# Altium schematic sheet BP6A_EVK_4.SchDoc(BP6A_EVK_4)
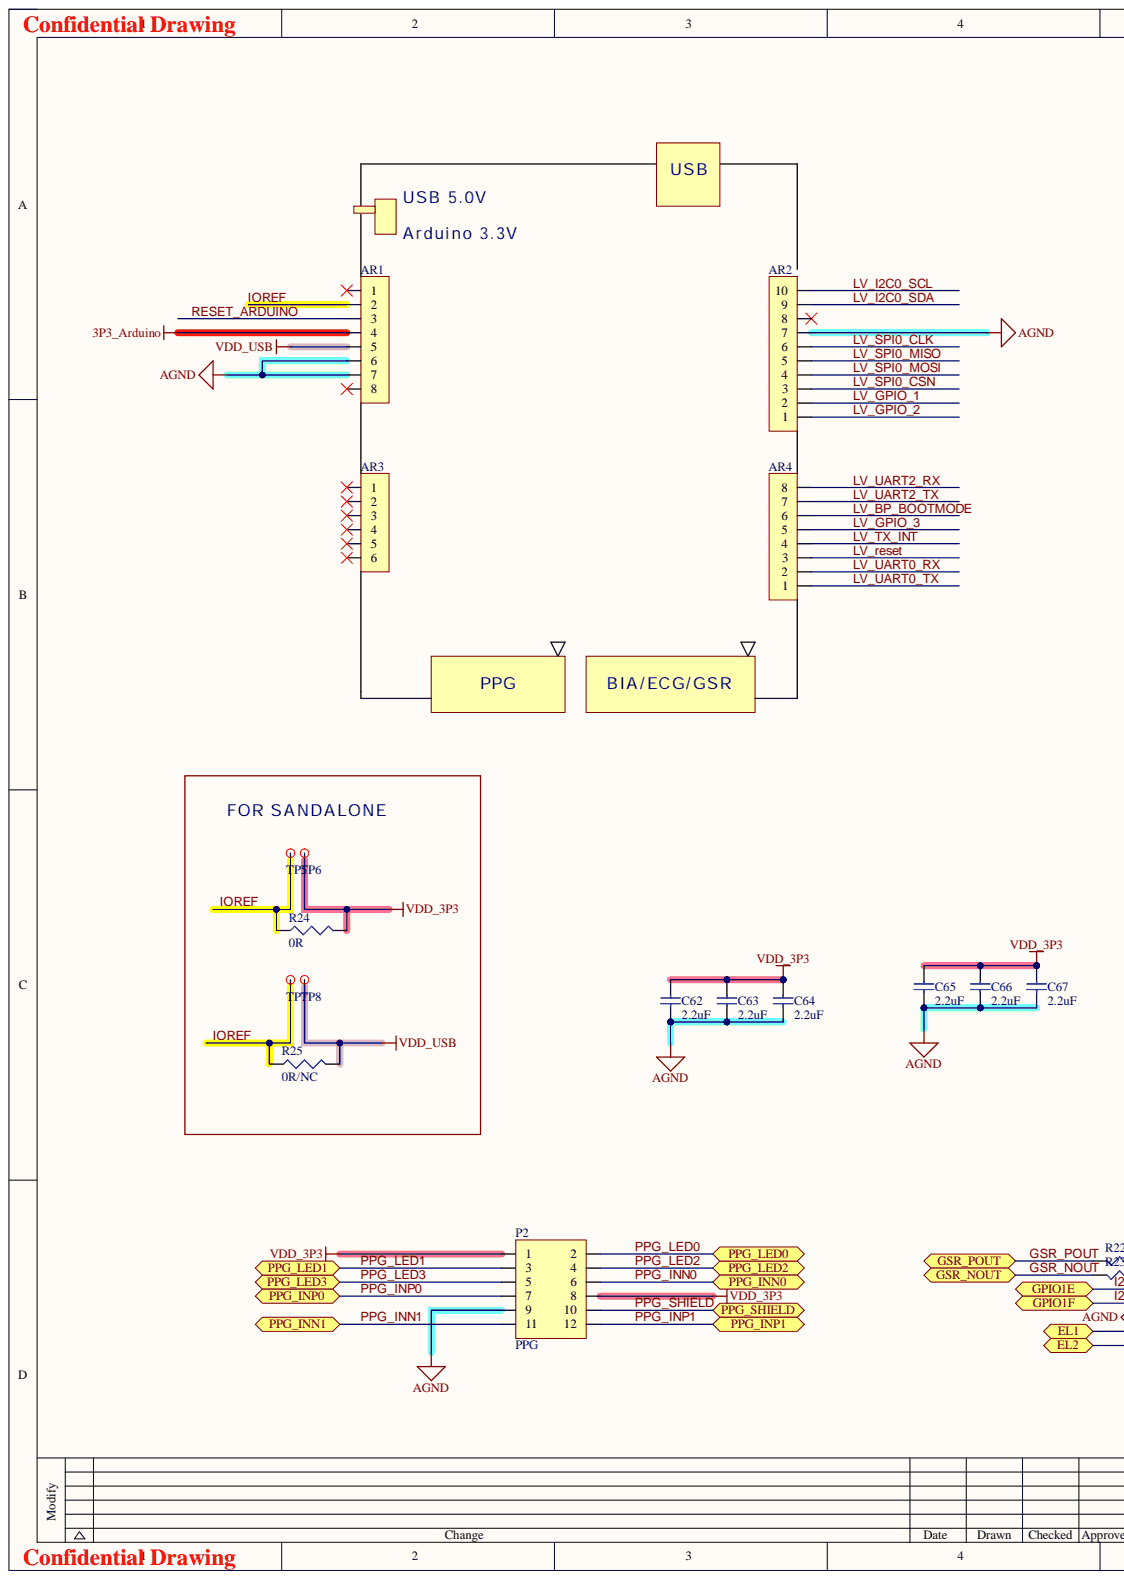
[diagram p0: BP6A_EVK_4.SchDoc, left]
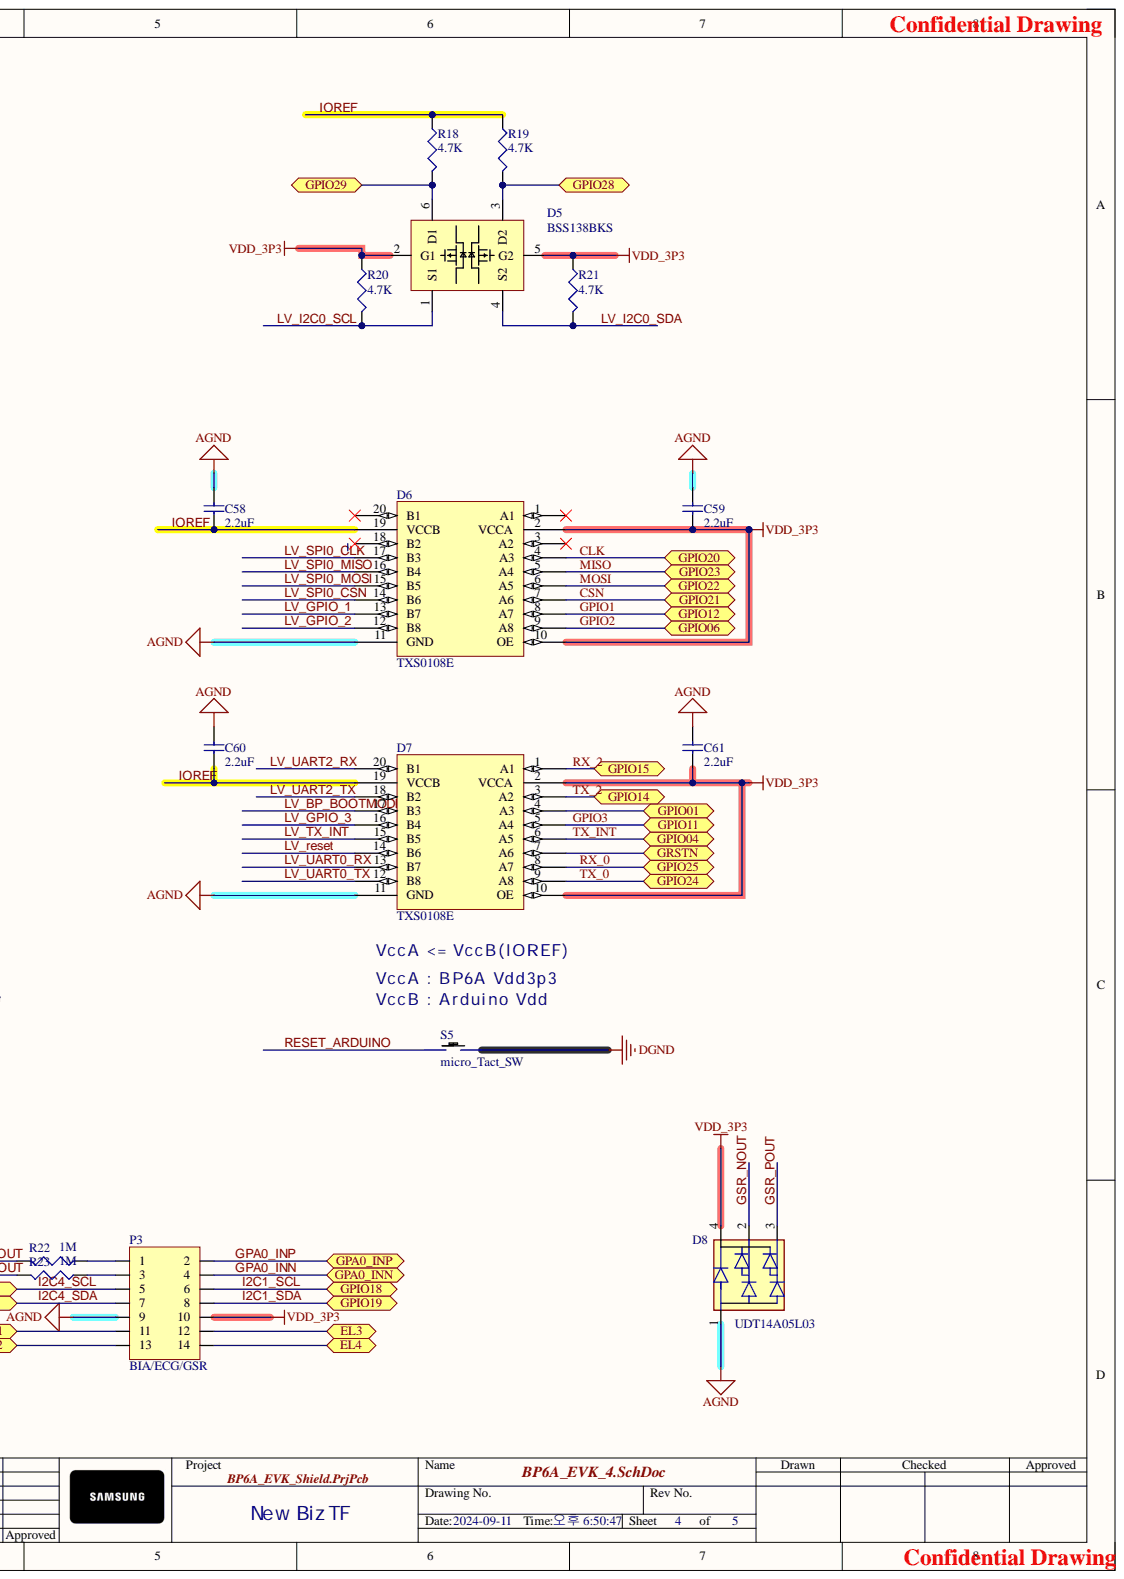
[diagram p1: BP6A_EVK_4.SchDoc, right]

## Parts
AR1  HEADER-8  [PIN8]  (value not drawn)
AR2  HEADER-10  [PIN10]  (value not drawn)
AR3  HEADER-6  [PIN6]  (value not drawn)
AR4  HEADER-8  [PIN8]  (value not drawn)
C58  2.2uF  [MLCC_1005]
C59  2.2uF  [MLCC_1005]
C60  2.2uF  [MLCC_1005]
C61  2.2uF  [MLCC_1005]
C62  2.2uF  [MLCC_1005]
C63  2.2uF  [MLCC_1005]
C64  2.2uF  [MLCC_1005]
C65  2.2uF  [MLCC_1005]
C66  2.2uF  [MLCC_1005]
C67  2.2uF  [MLCC_1005]
D5  BSS138BKS  [BSS138BKS_SOT363]
D6  TXS0108E  [TX0108E]
D7  TXS0108E  [TX0108E]
D8  UDT14A05L03  [SOT143]
P2  PPG  [HDR 6X2 Right]
P3  BIA/ECG/GSR  [HD7X2 RIGHT]
R18  4.7K  [RES_1005]
R19  4.7K  [RES_1005]
R20  4.7K  [RES_1005]
R21  4.7K  [RES_1005]
R22  1M  [RES_1005]
R23  1M  [RES_1005]
R24  0R  [RES_1005]
R25  0R/NC  [RES_1005]
S5  micro_Tact_SW  [micro_Tact_SW]
TP5  VIA  [TP_VIA]  (value not drawn)
TP6  VIA  [TP_VIA]  (value not drawn)
TP7  VIA  [TP_VIA]  (value not drawn)
TP8  VIA  [TP_VIA]  (value not drawn)

## Ports
EL1, EL2, EL3, EL4, GPA0_INN, GPA0_INP, GPIO01, GPIO1E, GPIO1F, GPIO04, GPIO06, GPIO11, GPIO12, GPIO14, GPIO15, GPIO18, GPIO19, GPIO20, GPIO21, GPIO22, GPIO23, GPIO24, GPIO25, GPIO28, GPIO29, GRSTN, GSR_NOUT, GSR_POUT, PPG_INN0, PPG_INN1, PPG_INP0, PPG_INP1, PPG_LED0, PPG_LED1, PPG_LED2, PPG_LED3, PPG_SHIELD

## Nets
3P3_Arduino: AR1.4
AGND: AR1.6 AR1.7 AR2.7 C58.2 C59.2 C60.2 C61.2 C62.1 C63.1 C64.1 C65.1 C66.1 C67.1 D6.11(GND) D7.11(GND) D8.1 P2.9 P3.9
DGND: S5.1
VDD_3P3: C59.1 C61.1 C62.2 C63.2 C64.2 C65.2 C66.2 C67.2 D5.2(G1) D5.5(G2) D6.2(VCCA) D6.10(OE) D7.2(VCCA) D7.10(OE) D8.4 P2.1 P2.8 P3.10 R20.1 R21.1 R24.2 TP6.1
VDD_USB: AR1.5 R25.2 TP8.1
CLK: D6.4(A3) ; port GPIO20
CSN: D6.7(A6) ; port GPIO21
EL1: P3.11 ; port EL1
EL2: P3.13 ; port EL2
EL3: P3.12 ; port EL3
EL4: P3.14 ; port EL4
GPA0_INN: P3.4 ; port GPA0_INN
GPA0_INP: P3.2 ; port GPA0_INP
GPIO1: D6.8(A7) ; port GPIO12
GPIO01: D7.4(A3) ; port GPIO01
GPIO2: D6.9(A8) ; port GPIO06
GPIO3: D7.5(A4) ; port GPIO11
GPIO28: D5.3(D2) R19.2 ; port GPIO28
GPIO29: D5.6(D1) R18.2 ; port GPIO29
GRSTN: D7.7(A6) ; port GRSTN
GSR_NOUT: D8.2 R23.2 ; port GSR_NOUT
GSR_POUT: D8.3 R22.2 ; port GSR_POUT
I2C1_SCL: P3.6 ; port GPIO18
I2C1_SDA: P3.8 ; port GPIO19
I2C4_SCL: P3.5 ; port GPIO1E
I2C4_SDA: P3.7 ; port GPIO1F
IOREF: AR1.2 C58.1 C60.1 D6.19(VCCB) D7.19(VCCB) R18.1 R19.1 R24.1 R25.1 TP5.1 TP7.1
LV_BP_BOOTMODE: AR4.6 D7.17(B3)
LV_GPIO_1: AR2.2 D6.13(B7)
LV_GPIO_2: AR2.1 D6.12(B8)
LV_GPIO_3: AR4.5 D7.16(B4)
LV_I2C0_SCL: AR2.10 D5.1(S1) R20.2
LV_I2C0_SDA: AR2.9 D5.4(S2) R21.2
LV_reset: AR4.3 D7.14(B6)
LV_SPI0_CLK: AR2.6 D6.17(B3)
LV_SPI0_CSN: AR2.3 D6.14(B6)
LV_SPI0_MISO: AR2.5 D6.16(B4)
LV_SPI0_MOSI: AR2.4 D6.15(B5)
LV_TX_INT: AR4.4 D7.15(B5)
LV_UART0_RX: AR4.2 D7.13(B7)
LV_UART0_TX: AR4.1 D7.12(B8)
LV_UART2_RX: AR4.8 D7.20(B1)
LV_UART2_TX: AR4.7 D7.18(B2)
MISO: D6.5(A4) ; port GPIO23
MOSI: D6.6(A5) ; port GPIO22
PPG_INN0: P2.6 ; port PPG_INN0
PPG_INN1: P2.11 ; port PPG_INN1
PPG_INP0: P2.7 ; port PPG_INP0
PPG_INP1: P2.12 ; port PPG_INP1
PPG_LED0: P2.2 ; port PPG_LED0
PPG_LED1: P2.3 ; port PPG_LED1
PPG_LED2: P2.4 ; port PPG_LED2
PPG_LED3: P2.5 ; port PPG_LED3
PPG_SHIELD: P2.10 ; port PPG_SHIELD
RESET_ARDUINO: AR1.3 S5.2
RX_0: D7.8(A7) ; port GPIO25
RX_2: D7.1(A1) ; port GPIO15
TX_0: D7.9(A8) ; port GPIO24
TX_2: D7.3(A2) ; port GPIO14
TX_INT: D7.6(A5) ; port GPIO04
NetP3_1: P3.1 R22.1
NetP3_3: P3.3 R23.1

Not connected: AR1 1 8; AR2 8; AR3 1 2 3 4 5 6; D6 1(A1) 3(A2) 18(B2) 20(B1)

## Library data of the parts
AR1 AR4 = HEADER-8: Connector; Component Kind: Standard; Library Name: my_components.IntLib; LINK: https://www.devicemart.co.kr/goods/view?no=1384238
AR2 = HEADER-10: Connector; Component Kind: Standard; Library Name: my_components.IntLib; LINK: https://www.devicemart.co.kr/goods/view?no=1384238
AR3 = HEADER-6: Connector; Component Kind: Standard; Library Name: my_components.IntLib; LINK: https://www.devicemart.co.kr/goods/view?no=1384238
C58-C67 = MLCC: Component Kind: Standard; Description: MLCC; Library Name: my_components.IntLib
D6 D7 = TXS0108E: 8Ch levelshifter; Component Kind: Standard; Library Name: my_component.SchLib
D8 = UDT14A05L03: MF: {'manufacturer': u'Littelfuse Inc.'}; MP: SP0503BAHTG; Availability: Unavailable; Component Kind: Standard; Description: UDT14A05L03; Library Name: my_component.SchLib; Package: None; Price: None
P2 = HDR 6X2 Right: Component Kind: Standard; Description: HDR 6X2 Right; Library Name: my_component.SchLib
P3 = HDR 7X2 Right: Component Kind: Standard; Description: HDR 7X2 Right; Library Name: my_component.SchLib
R18-R25 = Res: 0603Chip Resistor; Value: 10K; Component Kind: Standard; LatestRevisionDate: 29-May-2009; LatestRevisionNote: IPC-7351 Footprint Added.; PackageDescription: Chip Resistor; PackageReference: J1-0603; Published: 8-Jun-2000; Signal Integrity: Res; Simulation: RESISTOR; Library Name: pebble.SCHLIB; Publisher: Altium Limited
S5 = micro_Tact_SW: NW3-A06-B3; Component Kind: Standard; Library Name: my_component.SchLib
TP5-TP8 = TP_VIA: Component Kind: Standard; Library Name: my_component.SchLib
D5 = BSS138BKS: Component Kind: Standard; Description: BSS138BKS; Library Name: my_component.SchLib
